# Altium schematic sheet CPUBoard_p2.SchDoc
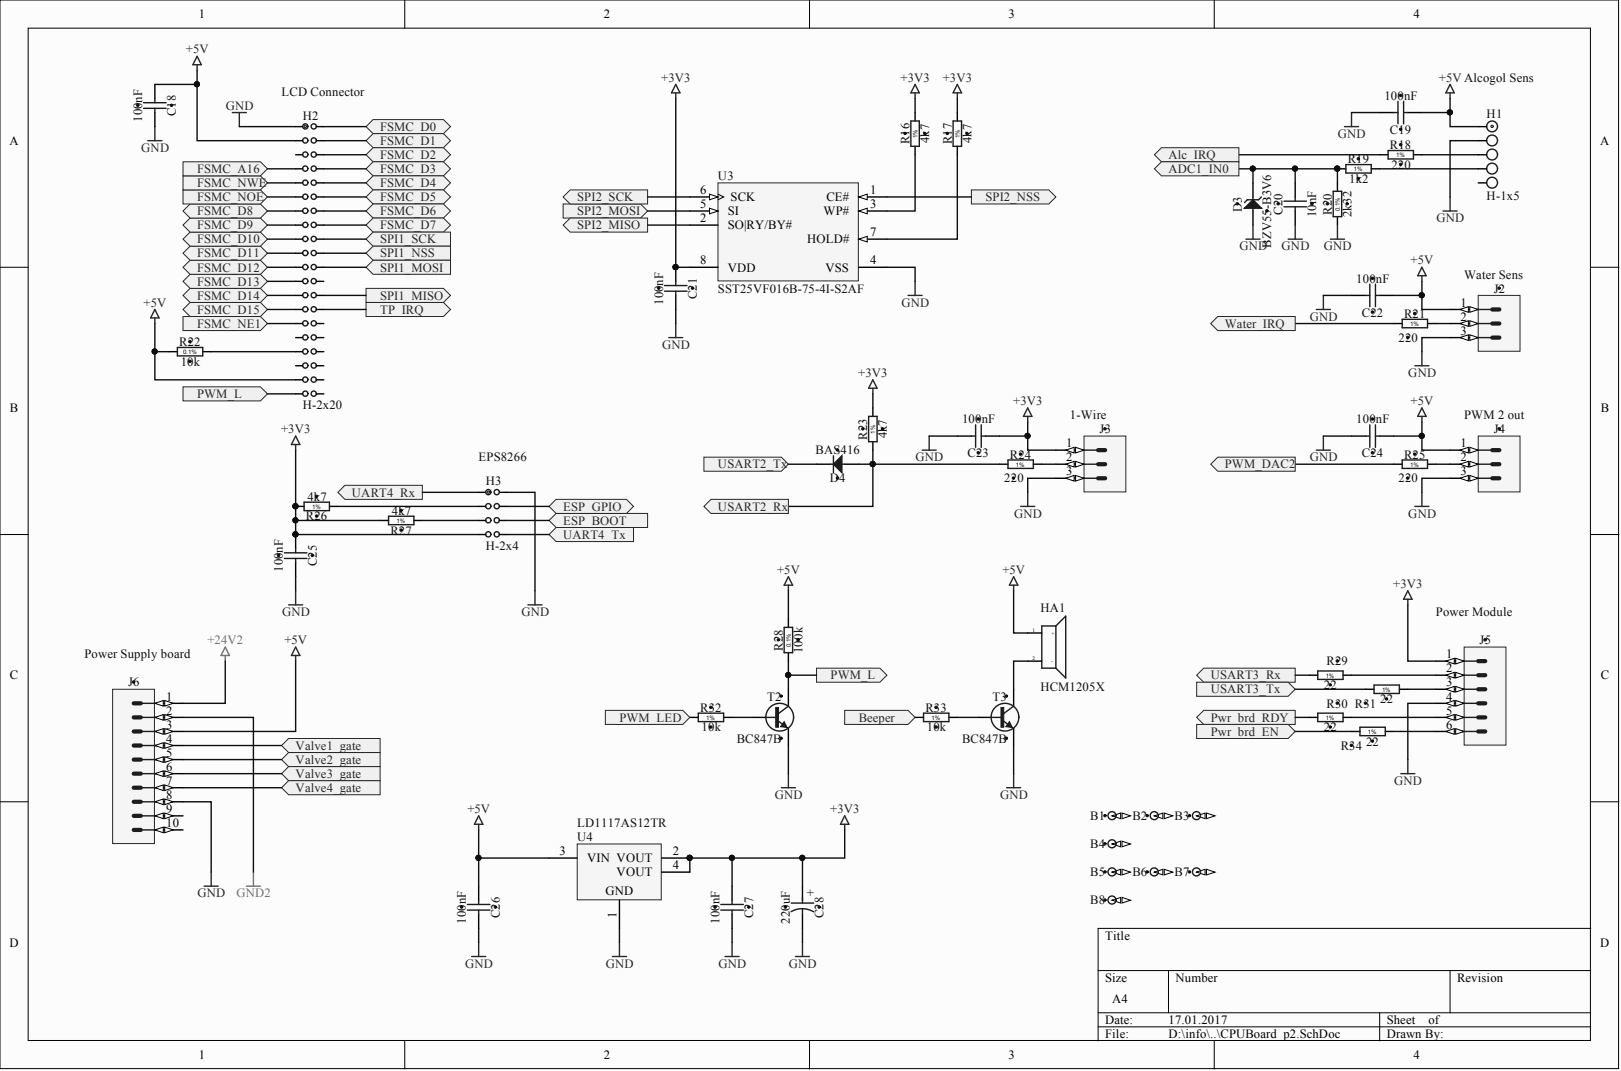
[diagram p0: CPUBoard_p2.SchDoc]

## Parts
B1  Plated Hole  [MTG350_650]  (value not drawn)  (no BOM)
B2  Plated Hole  [MTG350_650]  (value not drawn)  (no BOM)
B3  Plated Hole  [MTG350_650]  (value not drawn)  (no BOM)
B4  Plated Hole  [MTG350_650]  (value not drawn)  (no BOM)
B5  Plated Hole  [MTG350_650]  (value not drawn)  (no BOM)
B6  Plated Hole  [MTG350_650]  (value not drawn)  (no BOM)
B7  Plated Hole  [MTG350_650]  (value not drawn)  (no BOM)
B8  Plated Hole  [MTG350_650]  (value not drawn)  (no BOM)
C18  100nF  [CAPC2012X135N]
C19  100nF  [CAPC2012X135N]
C20  10nF  [CAPC2012X135N]
C21  100nF  [CAPC2012X135N]
C22  100nF  [CAPC2012X135N]
C23  100nF  [CAPC2012X135N]
C24  100nF  [CAPC2012X135N]
C25  100nF  [CAPC2012X135N]
C26  100nF  [CAPC2012X135N]
C27  100nF  [CAPC2012X135N]
C28  220uF  [CAPPR350-850X1300]
D3  BZV55-B3V6  [DIOMELF3515N]
D4  BAS416  [SOD2512X110N]
H1  H-1x5  [J1x5]
H2  H-2x20  [J2x20]
H3  H-2x4  [J2x4]
HA1  HCM1205X  [HCM1205X]
J2  KK®  [MOLEX_22-04-1031]  (value not drawn)
J3  KK®  [MOLEX_22-04-1031]  (value not drawn)
J4  KK®  [MOLEX_22-04-1031]  (value not drawn)
J5  KK®  [MOLEX_22-27-2061]  (value not drawn)
J6  C-Grid®  [MOLEX_70246-1004]  (value not drawn)
R16  4k7  [RESC2012X60N]
R17  4k7  [RESC2012X60N]
R18  220  [RESC2012X60N]
R19  1k2  [RESC2012X60N]
R20  2k32  [RESC2012X60N]
R21  220  [RESC2012X60N]
R22  10k  [RESC2012X60N]
R23  4k7  [RESC2012X60N]
R24  220  [RESC2012X60N]
R25  220  [RESC2012X60N]
R26  4k7  [RESC2012X60N]
R27  4k7  [RESC2012X60N]
R28  100k  [RESC2012X60N]
R29  22  [RESC2012X60N]
R30  22  [RESC2012X60N]
R31  22  [RESC2012X60N]
R32  10k  [RESC2012X60N]
R33  10k  [RESC2012X60N]
R34  22  [RESC2012X60N]
T2  BC847B  [SOT95P230X110-3N]
T3  BC847B  [SOT95P230X110-3N]
U3  SST25VF016B-75-4I-S2AF  [MCHP-SOIC-S2A8_N]
U4  LD1117AS12TR  [SOT-223_N]

## Ports
ADC1_IN0, ALC_IRQ, BEEPER, ESP_BOOT, ESP_GPIO, FSMC_A16, FSMC_D0, FSMC_D1, FSMC_D2, FSMC_D3, FSMC_D4, FSMC_D5, FSMC_D6, FSMC_D7, FSMC_D8, FSMC_D9, FSMC_D10, FSMC_D11, FSMC_D12, FSMC_D13, FSMC_D14, FSMC_D15, FSMC_NE1, FSMC_NOE, FSMC_NWE, PWM_DAC2, PWM_L, PWM_LED, PWR_BRD_EN, PWR_BRD_RDY, SPI1_MISO, SPI1_MOSI, SPI1_NSS, SPI1_SCK, SPI2_MISO, SPI2_MOSI, SPI2_NSS, SPI2_SCK, TP_IRQ, UART4_RX, UART4_TX, USART2_RX, USART2_TX, USART3_RX, USART3_TX, VALVE1_GATE, VALVE2_GATE, VALVE3_GATE, VALVE4_GATE, WATER_IRQ

## Nets
+3V3: C21.1 C23.1 C25.1 C27.1 C28.1 H3.7 J3.1 J5.1 R16.2 R17.2 R23.2 R26.2 R27.2 U3.8(VDD) U4.2(VOUT) U4.4(VOUT)
+5V: C18.1 C19.1 C22.1 C24.1 C26.1 H1.1 H2.3 H2.37 HA1.1 J2.1 J4.1 J6.3 R22.1 R28.2 U4.3(VIN)
+24V2: J6.1
GND: C18.2 C19.2 C20.1 C21.2 C22.2 C23.2 C24.2 C25.2 C26.2 C27.2 C28.2 D3.2 H1.2 H2.1 H3.2 J2.3 J3.3 J4.3 J5.4 J6.8 R20.1 T2.2 T3.2 U3.4(VSS) U4.1
GND2: J6.2
ADC1_IN0: C20.2 D3.1 R19.1 R20.2 ; port ADC1_IN0
ESP_BOOT: H3.6 ; port ESP_BOOT
ESP_GPIO: H3.4 ; port ESP_GPIO
FSMC_A16: H2.7 ; port FSMC_A16
FSMC_D0: H2.2 ; port FSMC_D0
FSMC_D1: H2.4 ; port FSMC_D1
FSMC_D2: H2.6 ; port FSMC_D2
FSMC_D3: H2.8 ; port FSMC_D3
FSMC_D4: H2.10 ; port FSMC_D4
FSMC_D5: H2.12 ; port FSMC_D5
FSMC_D6: H2.14 ; port FSMC_D6
FSMC_D7: H2.16 ; port FSMC_D7
FSMC_D8: H2.13 ; port FSMC_D8
FSMC_D9: H2.15 ; port FSMC_D9
FSMC_D10: H2.17 ; port FSMC_D10
FSMC_D11: H2.19 ; port FSMC_D11
FSMC_D12: H2.21 ; port FSMC_D12
FSMC_D13: H2.23 ; port FSMC_D13
FSMC_D14: H2.25 ; port FSMC_D14
FSMC_D15: H2.27 ; port FSMC_D15
FSMC_NE1: H2.29 ; port FSMC_NE1
FSMC_NOE: H2.11 ; port FSMC_NOE
FSMC_NWE: H2.9 ; port FSMC_NWE
PWM_DAC2: R25.1 ; port PWM_DAC2
PWM_L: H2.39 R28.1 T2.3 ; port PWM_L
PWM_LED: R32.1 ; port PWM_LED
SPI1_MISO: H2.26 ; port SPI1_MISO
SPI1_MOSI: H2.22 ; port SPI1_MOSI
SPI1_NSS: H2.20 ; port SPI1_NSS
SPI1_SCK: H2.18 ; port SPI1_SCK
SPI2_MISO: U3.2(SO|RY/BY#) ; port SPI2_MISO
SPI2_MOSI: U3.5(SI) ; port SPI2_MOSI
SPI2_NSS: U3.1(CE#) ; port SPI2_NSS
SPI2_SCK: U3.6(SCK) ; port SPI2_SCK
TP_IRQ: H2.28 ; port TP_IRQ
NetD4_1: D4.1 ; port USART2_TX
NetD4_2: D4.2 R23.1 R24.1 ; port USART2_RX
NetH1_3: H1.3 R18.2
NetH1_4: H1.4 R19.2
NetH2_33: H2.33 R22.2
NetH3_1: H3.1 ; port UART4_RX
NetH3_3: H3.3 R26.1
NetH3_5: H3.5 R27.1
NetH3_8: H3.8 ; port UART4_TX
NetHA1_2: HA1.2 T3.3
NetJ2_2: J2.2 R21.2
NetJ3_2: J3.2 R24.2
NetJ4_2: J4.2 R25.2
NetJ5_2: J5.2 R29.2
NetJ5_3: J5.3 R31.2
NetJ5_5: J5.5 R30.2
NetJ5_6: J5.6 R34.2
NetJ6_4: J6.4 ; port VALVE1_GATE
NetJ6_5: J6.5 ; port VALVE2_GATE
NetJ6_6: J6.6 ; port VALVE3_GATE
NetJ6_7: J6.7 ; port VALVE4_GATE
NetR16_1: R16.1 U3.3(WP#)
NetR17_1: R17.1 U3.7(HOLD#)
NetR18_1: R18.1 ; port ALC_IRQ
NetR21_1: R21.1 ; port WATER_IRQ
NetR29_1: R29.1 ; port USART3_RX
NetR30_1: R30.1 ; port PWR_BRD_RDY
NetR31_1: R31.1 ; port USART3_TX
NetR32_2: R32.2 T2.1
NetR33_1: R33.1 ; port BEEPER
NetR33_2: R33.2 T3.1
NetR34_1: R34.1 ; port PWR_BRD_EN

Not connected: B1 1; B2 1; B3 1; B4 1; B5 1; B6 1; B7 1; B8 1; H1 5; H2 5 24 30 31 32 34 35 36 38 40; J6 9 10

## Library data of the parts
B1-B8 = PLATED_HOLE3.5_PAD6.5: Single Terminal Socket; Part Description: Plated Through Hole: Hole Dia.=3.5mm Pad Dia.=6.5mm; Database Table Name: Pads; Mounted: No; ComponentHeight: 0mm; Component Type: Standard (No BOM); CreateDate: 20.11.2008; Family: Plated Hole; LatestRevisionDate: 23.11.2011; Library Name: Eletro-mechanical.DbLib; PackageDescription: Plated Through Hole: Hole Dia.=3.5mm Pad Dia.=6.5mm; Part Number: PLATED_HOLE3.5_PAD6.5; Pin Count: 1; SMD: No; Socket: No; Status: None; Table: Pads; Author: CERN DEM JLC
C18 C19 C21-C27 = CC0805_100NF_50V_10%_X7R: Non-polarised capacitor; Manufacturer: GENERIC; Manufacturer1 Part Number: B37941K5104K62; Part Description: SMD Multilayer Chip Ceramic Capacitor; Database Table Name: Capacitors SMD; TC: X7R; Tolerance: ±10%; Voltage: 50V; Case: 0805; Component Kind: Standard; Component Type: Standard; CreateDate: 03.12.2007; HelpURL: \\cern.ch\dfs\Applications\Altium\Datasheets\CC0805_X7R_EPCOS.pdf; LatestRevisionDate: 03.12.2007; Library Name: Capacitors.DbLib; Manufacturer1 ComponentHeight: 1.35mm; Manufacturer1 Example: EPCOS; Manufacturer Part Number: CC0805_100NF_50V_10%_X7R; Mounted: Yes; Part Number: CC0805_100NF_50V_10%_X7R; Pin Count: 2; SMD: Yes; Socket: No; Status: Preferred; Table: Capacitors SMD; Value: 100nF; Author: CERN DEM JLC
C20 = CC0805_10NF_50V_5%_X7R: Non-polarised capacitor; Manufacturer: GENERIC; Manufacturer1 Part Number: 08055C103JAT2A; Part Description: SMD Multilayer Chip Ceramic Capacitor; Database Table Name: Capacitors SMD; TC: X7R; Tolerance: ±5%; Voltage: 50V; Case: 0805; Component Kind: Standard; Component Type: Standard; CreateDate: 18.01.2011; HelpURL: \\cern.ch\dfs\Applications\Altium\Datasheets\CC0805_X7R_AVX.pdf; LatestRevisionDate: 18.01.2011; Library Name: Capacitors.DbLib; Manufacturer1 ComponentHeight: 0.94mm; Manufacturer1 Example: AVX; Manufacturer Part Number: CC0805_10NF_50V_5%_X7R; Mounted: Yes; Part Number: CC0805_10NF_50V_5%_X7R; Pin Count: 2; SMD: Yes; Socket: No; Status: None; Table: Capacitors SMD; Value: 10nF; Author: CERN DEM JLC
C28 = CAER_220UF_25V_20%_RUBYCON_25YXF220M8X11.5: Polarised capacitor; Part Description: Radial Miniature, Aluminum Electrolytic Capacitor, (YXF Series); Database Table Name: Capacitors THD; Tolerance: ±20%; Voltage: 25V; Case: LS3.5 D8.5 x H13; ComponentHeight: 13mm; Component Kind: Standard; Component Type: Standard; CreateDate: 20.08.2009; HelpURL: \\cern.ch\dfs\Applications\Altium\Datasheets\CAER_RUBYCON_YXF.pdf; LatestRevisionDate: 17.09.2009; Library Name: Capacitors.DbLib; Manufacturer: RUBYCON; Manufacturer Part Number: 25YXF220M8X11.5; Mounted: Yes; Part Number: CAER_220UF_25V_20%_RUBYCON_25YXF220M8X11.5; Pin Count: 2; SMD: No; Socket: No; Status: None; Table: Capacitors THD; Value: 220uF; Author: CERN DEM JLC
D3 = BZV55-B3V6: Zener Diode; Manufacturer: NXP SEMICONDUCTORS; Part Description: Voltage Regulator Diode; Database Table Name: Diodes; Power: 500mW; Voltage: 3V6; Case: SOD-80C; ComponentHeight: 1.6mm; Component Kind: Standard; Component Type: Standard; CreateDate: 06.05.2008; Device: BZV55-B3V6; HelpURL: \\cern.ch\dfs\Applications\Altium\Datasheets\BZV55.pdf; LatestRevisionDate: 06.05.2008; Library Name: ICs And Semiconductors.DbLib; Manufacturer Part Number: BZV55-B3V6; Mounted: Yes; PackageDescription: SOD80C, MELF Diode, 2-Leads, Body 3.5x1.5mm, IPC Medium Density; Part Number: BZV55-B3V6; Pin Count: 2; SMD: Yes; Socket: No; Table: Diodes; Author: CERN DEM JLC
D4 = BAS416: Diode; Manufacturer: NXP SEMICONDUCTORS; Part Description: Low-Leakage Diode; Database Table Name: Diodes; Power: 200mA; Voltage: 75V; Case: SOD323; ComponentHeight: 1.1mm; Component Kind: Standard; Component Type: Standard; CreateDate: 01.10.2013; Device: BAS416; HelpURL: \\cern.ch\dfs\Applications\Altium\Datasheets\BAS416.pdf; LatestRevisionDate: 01.10.2013; Library Name: ICs And Semiconductors.DbLib; Manufacturer Part Number: BAS416; Mounted: Yes; PackageDescription: Small Outline Diode Flat Lead (SOD-323), 1.70mm W X 1.25mm L X 1.10mm H body,  IPC Medium Density; Part Number: BAS416; Pin Count: 2; SMD: Yes; Socket: No; Table: Diodes; Author: CERN DEM JLC
J2-J4 = MOLEX_22-04-1031: Connector 3 Male; Part Description: 2.5mm (.100") Pitch KK® Wire-to-Board Header, Vertical, with Friction Lock, 3 Circuits, Tin (Sn) Plating; Database Table Name: MOLEX; ComponentHeight: 11.7mm; Component Kind: Standard; Component Type: Standard; CreateDate: 09.02.2012; Family: KK®; HelpURL: \\cern.ch\dfs\Applications\Altium\Datasheets\MOLEX_22-04-1031.pdf; LatestRevisionDate: 09.02.2012; Library Name: Connectors.DbLib; Manufacturer: MOLEX; Manufacturer Part Number: 22-04-1031; Mounted: Yes; Part Number: MOLEX_22-04-1031; Pin Count: 3; SMD: No; Socket: No; Status: None; Table: MOLEX; Author: CERN DEM JLC
J5 = MOLEX_22-27-2061: Connector 6 Male; Part Description: 2.54mm (.100") Pitch KK® Wire-to-Board Header, Vertical, with Friction Lock, 6 Circuits, Tin (Sn) Plating; Database Table Name: MOLEX; ComponentHeight: 11.7mm; Component Kind: Standard; Component Type: Standard; CreateDate: 30.11.2011; Family: KK®; HelpURL: \\cern.ch\dfs\Applications\Altium\Datasheets\MOLEX_22-27-2061.pdf; LatestRevisionDate: 30.11.2011; Library Name: Connectors.DbLib; Manufacturer: MOLEX; Manufacturer Part Number: 22-27-2061; Mounted: Yes; Part Number: MOLEX_22-27-2061; Pin Count: 6; SMD: No; Socket: No; Status: None; Table: MOLEX; Author: CERN DEM JMW
J6 = MOLEX_70246-1004: Connector 10 Male; Part Description: 2.54mm Pitch C-Grid® Header, Low Profile, Dual Row, Vertical, Shrouded Header; Database Table Name: MOLEX; ComponentHeight: 9.27mm; Component Kind: Standard; Component Type: Standard; CreateDate: 14.08.2013; Family: C-Grid®; HelpURL: \\cern.ch\dfs\Applications\Altium\Datasheets\MOLEX_70246-1004.pdf; LatestRevisionDate: 14.08.2013; Library Name: Connectors.DbLib; Manufacturer: MOLEX; Manufacturer Part Number: 70246-1004; Mounted: Yes; Part Number: MOLEX_70246-1004; Pin Count: 10; SMD: No; Socket: No; Status: None; Table: MOLEX; Author: CERN DEM JLC
R16 R17 R23 R26 R27 = R0805_4K7_1%_0.125W_100PPM: Resistor - 1%; Manufacturer: GENERIC; Manufacturer1 Part Number: NRC10F4701TRF; Part Description: General Purpose Thick Film Chip Resistor; Database Table Name: Resistors SMD; Power: 0.125W; TC: ±100ppm/°C; Case: 0805; Component Kind: Standard; Component Type: Standard; CreateDate: 03.12.2007; HelpURL: \\cern.ch\dfs\Applications\Altium\Datasheets\R0805_NIC_NRC.pdf; LatestRevisionDate: 13.03.2008; Library Name: Resistors.DbLib; Manufacturer1 ComponentHeight: 0.6mm; Manufacturer1 Example: NIC COMPONENT; Manufacturer Part Number: R0805_4K7_1%_0.125W_100PPM; Mounted: Yes; Part Number: R0805_4K7_1%_0.125W_100PPM; Pin Count: 2; SMD: Yes; Socket: No; Status: Preferred; Table: Resistors SMD; Tolerance: ±1%; Value: 4k7; Author: CERN DEM JLC
R18 R21 R24 R25 = R0805_220R_1%_0.125W_100PPM: Resistor - 1%; Manufacturer: GENERIC; Manufacturer1 Part Number: NRC10F2200TRF; Part Description: General Purpose Thick Film Chip Resistor; Database Table Name: Resistors SMD; Power: 0.125W; TC: ±100ppm/°C; Case: 0805; Component Kind: Standard; Component Type: Standard; CreateDate: 03.12.2007; HelpURL: \\cern.ch\dfs\Applications\Altium\Datasheets\R0805_NIC_NRC.pdf; LatestRevisionDate: 13.03.2008; Library Name: Resistors.DbLib; Manufacturer1 ComponentHeight: 0.6mm; Manufacturer1 Example: NIC COMPONENT; Manufacturer Part Number: R0805_220R_1%_0.125W_100PPM; Mounted: Yes; Part Number: R0805_220R_1%_0.125W_100PPM; Pin Count: 2; SMD: Yes; Socket: No; Status: Preferred; Table: Resistors SMD; Tolerance: ±1%; Value: 220; Author: CERN DEM JLC
R19 = R0805_1K2_1%_0.125W_100PPM: Resistor - 1%; Manufacturer: GENERIC; Manufacturer1 Part Number: NRC10F1201TRF; Part Description: General Purpose Thick Film Chip Resistor; Database Table Name: Resistors SMD; Power: 0.125W; TC: ±100ppm/°C; Case: 0805; Component Kind: Standard; Component Type: Standard; CreateDate: 03.12.2007; HelpURL: \\cern.ch\dfs\Applications\Altium\Datasheets\R0805_NIC_NRC.pdf; LatestRevisionDate: 13.03.2008; Library Name: Resistors.DbLib; Manufacturer1 ComponentHeight: 0.6mm; Manufacturer1 Example: NIC COMPONENT; Manufacturer Part Number: R0805_1K2_1%_0.125W_100PPM; Mounted: Yes; Part Number: R0805_1K2_1%_0.125W_100PPM; Pin Count: 2; SMD: Yes; Socket: No; Status: Preferred; Table: Resistors SMD; Tolerance: ±1%; Value: 1k2; Author: CERN DEM JLC
R20 = R0805_2K32_0.1%_0.1W_10PPM: Resistor - 0.1%; Manufacturer: GENERIC; Manufacturer1 Part Number: RN73C2A2K32BTG; Part Description: Thin Film Chip Resistor; Database Table Name: Resistors SMD; Power: 0.1W; TC: ±10ppm; Case: 0805; Component Kind: Standard; Component Type: Standard; CreateDate: 03.12.2007; HelpURL: \\cern.ch\dfs\Applications\Altium\Datasheets\R0805_Tyco_RN73.pdf; LatestRevisionDate: 13.03.2008; Library Name: Resistors.DbLib; Manufacturer1 ComponentHeight: 0.6mm; Manufacturer1 Example: TYCO HOLSWORTHY; Manufacturer Part Number: R0805_2K32_0.1%_0.1W_10PPM; Mounted: Yes; Part Number: R0805_2K32_0.1%_0.1W_10PPM; Pin Count: 2; SMD: Yes; Socket: No; Status: Not recommended; Table: Resistors SMD; Tolerance: ±0.1%; Value: 2k32; Author: CERN DEM JLC
R22 = R0805_10K_0.1%_0.1W_10PPM: Resistor - 0.1%; Manufacturer: GENERIC; Manufacturer1 Part Number: RN73C2A10KBTG; Part Description: Thin Film Chip Resistor; Database Table Name: Resistors SMD; Power: 0.1W; TC: ±10ppm; Case: 0805; Component Kind: Standard; Component Type: Standard; CreateDate: 03.12.2007; HelpURL: \\cern.ch\dfs\Applications\Altium\Datasheets\R0805_Tyco_RN73.pdf; LatestRevisionDate: 13.03.2008; Library Name: Resistors.DbLib; Manufacturer1 ComponentHeight: 0.6mm; Manufacturer1 Example: TYCO HOLSWORTHY; Manufacturer Part Number: R0805_10K_0.1%_0.1W_10PPM; Mounted: Yes; Part Number: R0805_10K_0.1%_0.1W_10PPM; Pin Count: 2; SMD: Yes; Socket: No; Status: Not recommended; Table: Resistors SMD; Tolerance: ±0.1%; Value: 10k; Author: CERN DEM JLC
R28 = R0805_100K_0.1%_0.1W_10PPM: Resistor - 0.1%; Manufacturer: GENERIC; Manufacturer1 Part Number: RN73C2A100KBTG; Part Description: Thin Film Chip Resistor; Database Table Name: Resistors SMD; Power: 0.1W; TC: ±10ppm; Case: 0805; Component Kind: Standard; Component Type: Standard; CreateDate: 03.12.2007; HelpURL: \\cern.ch\dfs\Applications\Altium\Datasheets\R0805_Tyco_RN73.pdf; LatestRevisionDate: 13.03.2008; Library Name: Resistors.DbLib; Manufacturer1 ComponentHeight: 0.6mm; Manufacturer1 Example: TYCO HOLSWORTHY; Manufacturer Part Number: R0805_100K_0.1%_0.1W_10PPM; Mounted: Yes; Part Number: R0805_100K_0.1%_0.1W_10PPM; Pin Count: 2; SMD: Yes; Socket: No; Status: Not recommended; Table: Resistors SMD; Tolerance: ±0.1%; Value: 100k; Author: CERN DEM JLC
R29-R31 R34 = R0805_22R_1%_0.125W_100PPM: Resistor - 1%; Manufacturer: GENERIC; Manufacturer1 Part Number: NRC10F22R0TRF; Part Description: General Purpose Thick Film Chip Resistor; Database Table Name: Resistors SMD; Power: 0.125W; TC: ±100ppm/°C; Case: 0805; Component Kind: Standard; Component Type: Standard; CreateDate: 03.12.2007; HelpURL: \\cern.ch\dfs\Applications\Altium\Datasheets\R0805_NIC_NRC.pdf; LatestRevisionDate: 13.03.2008; Library Name: Resistors.DbLib; Manufacturer1 ComponentHeight: 0.6mm; Manufacturer1 Example: NIC COMPONENT; Manufacturer Part Number: R0805_22R_1%_0.125W_100PPM; Mounted: Yes; Part Number: R0805_22R_1%_0.125W_100PPM; Pin Count: 2; SMD: Yes; Socket: No; Status: Preferred; Table: Resistors SMD; Tolerance: ±1%; Value: 22; Author: CERN DEM JLC
R32 R33 = R0805_10K_1%_0.125W_100PPM: Resistor - 1%; Manufacturer: GENERIC; Manufacturer1 Part Number: NRC10F1002TRF; Part Description: General Purpose Thick Film Chip Resistor; Database Table Name: Resistors SMD; Power: 0.125W; TC: ±100ppm/°C; Case: 0805; Component Kind: Standard; Component Type: Standard; CreateDate: 03.12.2007; HelpURL: \\cern.ch\dfs\Applications\Altium\Datasheets\R0805_NIC_NRC.pdf; LatestRevisionDate: 13.03.2008; Library Name: Resistors.DbLib; Manufacturer1 ComponentHeight: 0.6mm; Manufacturer1 Example: NIC COMPONENT; Manufacturer Part Number: R0805_10K_1%_0.125W_100PPM; Mounted: Yes; Part Number: R0805_10K_1%_0.125W_100PPM; Pin Count: 2; SMD: Yes; Socket: No; Status: Preferred; Table: Resistors SMD; Tolerance: ±1%; Value: 10k; Author: CERN DEM JLC
T2 T3 = BC847B: NPN Transistor; Manufacturer: NXP SEMICONDUCTORS; Part Description: 45V 100mA NPN general-purpose transistors; Database Table Name: Transistors; Case: SOT23-3; ComponentHeight: 1.1mm; Component Kind: Standard; Component Type: Standard; CreateDate: 14.06.2011; Device: BC847B; HelpURL: \\cern.ch\dfs\Applications\Altium\Datasheets\BC847B.pdf; LatestRevisionDate: 14.06.2011; Library Name: ICs And Semiconductors.DbLib; Manufacturer Part Number: BC847B; Mounted: Yes; PackageDescription: SOT23, 3 pin, Pitch 0.95mm - 1.3mm W X 2.9mm L X 1.1mm H, IPC Medium Density; Part Number: BC847B; Pin Count: 3; SMD: Yes; Socket: No; Table: Transistors; Author: CERN DEM JLC
U3 = SST25VF016B-75-4I-S2AF: 16 Mbit SPI Serial Flash, 2.7 to 3.6 V, 80 MHz, -40 to 85 degC, 8-pin SOIC (S2A8), Tube; Manufacturer: Microchip; Component Kind: Standard; ComponentLink1Description: Manufacturer URL; ComponentLink1URL: http://www.microchip.com; ComponentLink2Description: Datasheet; ComponentLink2URL: http://ww1.microchip.com/downloads/en/DeviceDoc/25044A.pdf; ComponentLink3Description: Package Specification; ComponentLink3URL: http://ww1.microchip.com/downloads/en/DeviceDoc/25051A.pdf; ComponentLink4Description: Product URL; ComponentLink4URL: http://www.microchip.com/SST25VF016B; DatasheetVersion: Rev. A; Library Name: Microchip SST Flash.IntLib; Mounting Technology: Surface Mount; PackageDescription: 8-Lead Plastic Small Outline Intergrated Circuit, 5.275 x 5.275 mm Body, 1.27 mm Pitch; PackageReference: SOIC-S2A8; PackageVersion: Rev. A; PartNumber: SST25VF016B-75-4I-S2AF; Supplier 1: Digi-Key; Supplier 2: Farnell; Supplier 3: Newark; Supplier 4: Mouser; Supplier Part Number 1: SST25VF016B-75-4I-S2AF-ND; Supplier Part Number 2: 1829951; Supplier Part Number 3: 82R4475; Supplier Part Number 4: 579-25VF016B7IS2AF
U4 = LD1117AS12TR: 1A, Low Drop Positive Voltage Regulator, 1.2V, 4-Pin SOT-223, Tape and Reel; Component Kind: Standard; ComponentLink1Description: Manufacturer URL; ComponentLink1URL: http://www.st.com; ComponentLink2Description: Datasheet; ComponentLink2URL: http://www.st.com/internet/com/TECHNICAL_RESOURCES/TECHNICAL_LITERATURE/DATASHEET/CD00002116.pdf; DatasheetVersion: Dec-2011; Library Name: STMicroelectronics Power Management Linear Regulator.IntLib; PackageDescription: 4-Pin SOT-223 Package, 6.5 x 3.5 mm Body, 2.3 mm Pitch, 7 mm Lead Span; PackageReference: SOT-223; PackageVersion: 2010; PartNumber: LD1117AS12TR
H1 = H-1x5: Component Kind: Standard; Library Name: Connektor.IntLib; Price: 0.05
H2 = H-2x20: Component Kind: Standard; Library Name: Connektor.IntLib; Price: 0.40
H3 = H-2x4: Component Kind: Standard; Library Name: Connektor.IntLib; Price: 0.08
HA1 = HCM1205X: Component Kind: Standard; Description: HCM1205X; Library Name: PowerLib.SchLib
